# Altium schematic sheet Schematic_Kpad.SchDoc
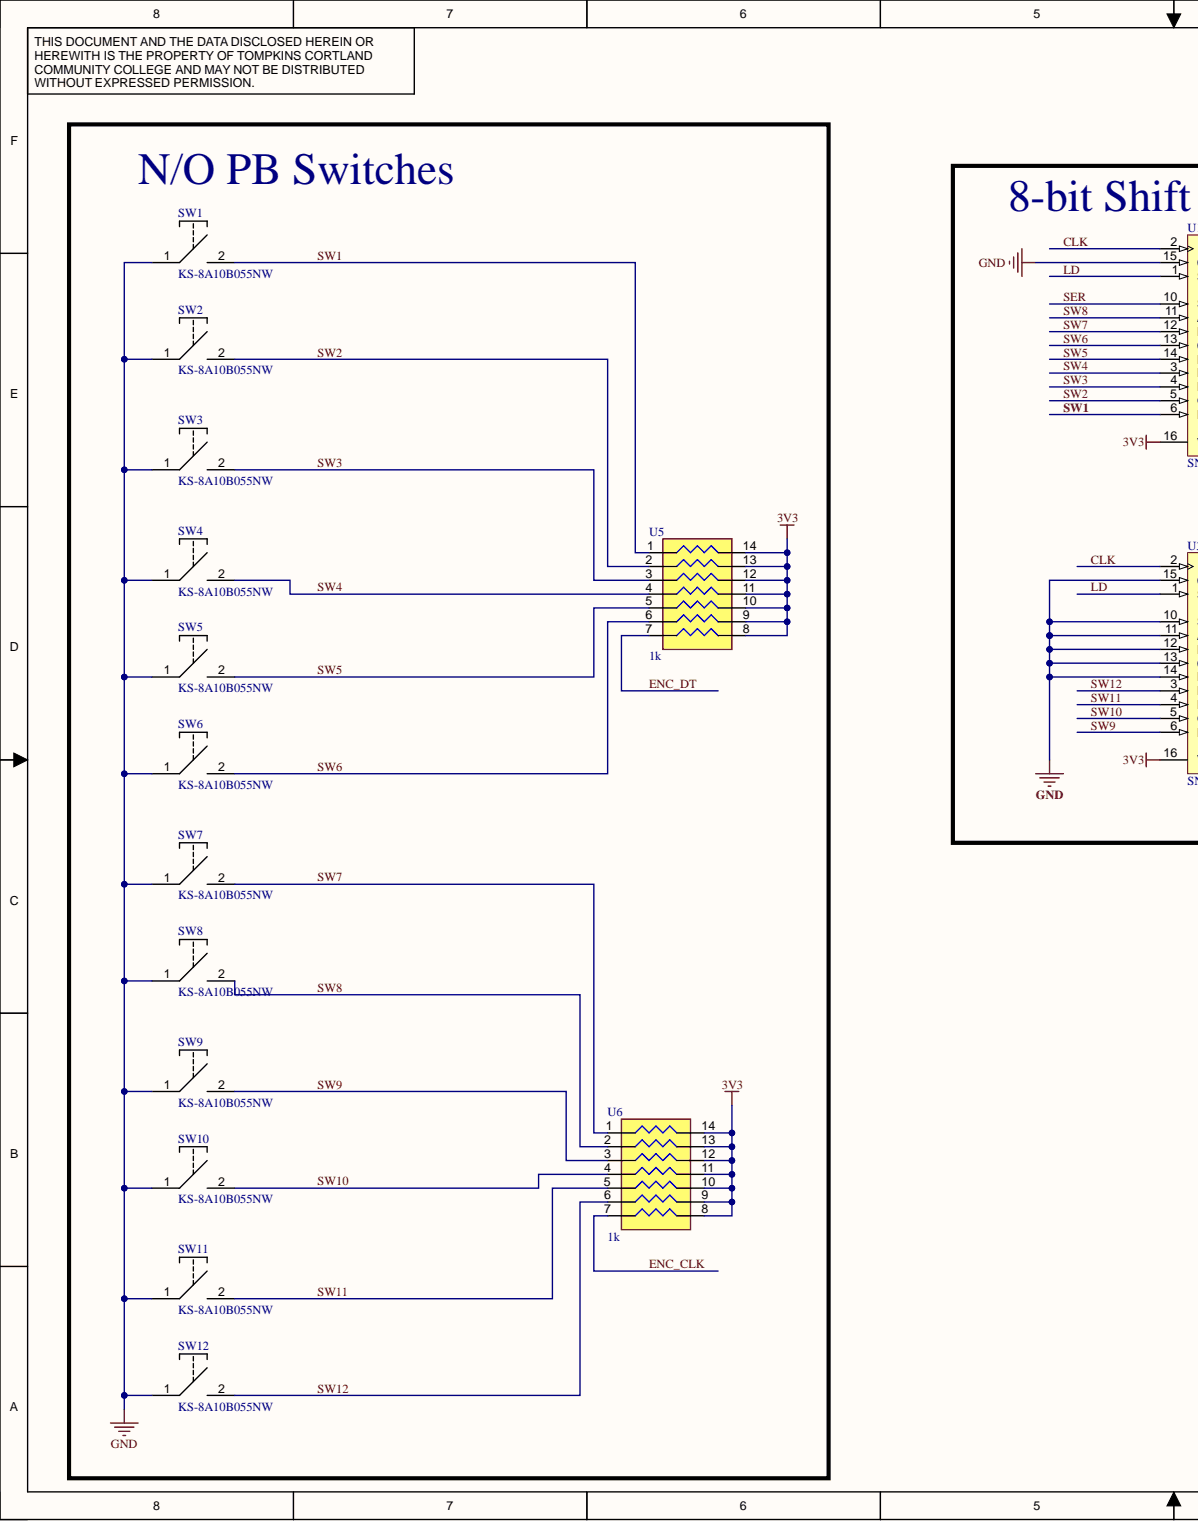
[diagram p0: Schematic_Kpad.SchDoc, left]
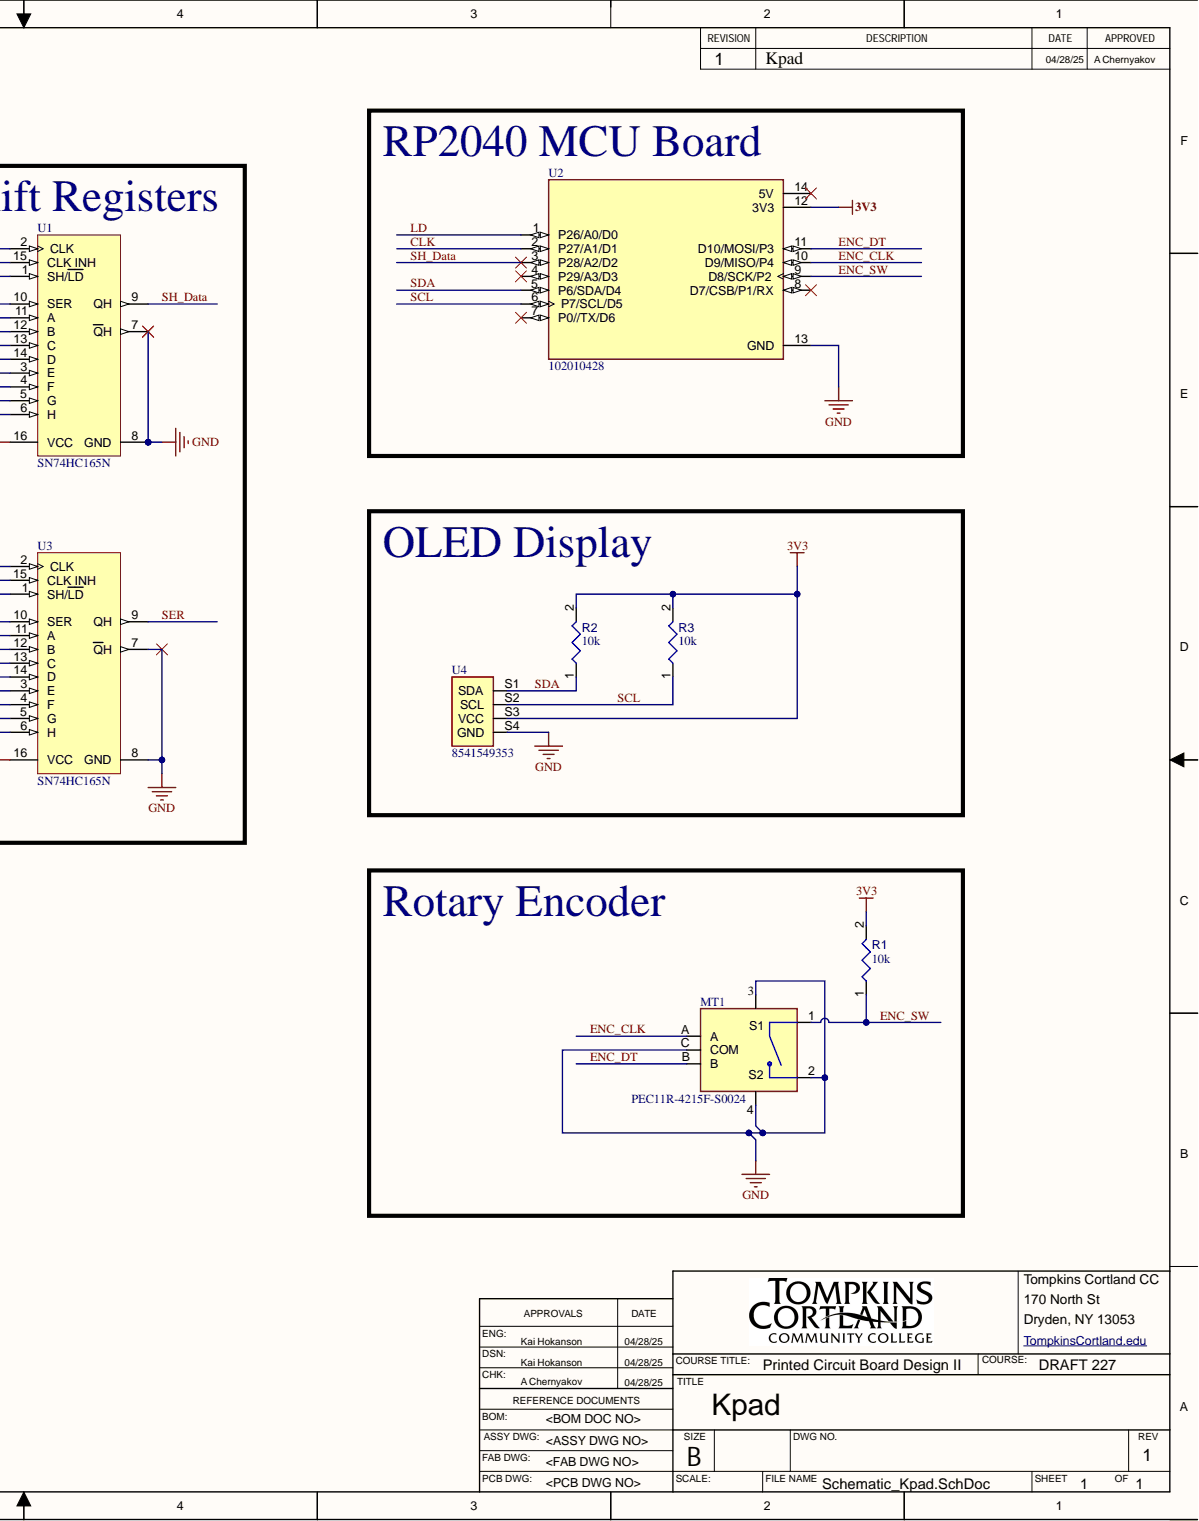
[diagram p1: Schematic_Kpad.SchDoc, right]

## Parts
MT1  PEC11R-4215F-S0024  [FP-PEC11R-4215F-S0024-MFG]
R1  value 10k  [RESA60-630X240]  (hidden comment: MFR-25FBF52-10K)
R2  value 10k  [RESA60-630X240]  (hidden comment: MFR-25FBF52-10K)
R3  value 10k  [RESA60-630X240]  (hidden comment: MFR-25FBF52-10K)
SW1  KS-8A10B055NW  [KS-8A10B055NW-Footprint-1]
SW2  KS-8A10B055NW  [KS-8A10B055NW-Footprint-1]
SW3  KS-8A10B055NW  [KS-8A10B055NW-Footprint-1]
SW4  KS-8A10B055NW  [KS-8A10B055NW-Footprint-1]
SW5  KS-8A10B055NW  [KS-8A10B055NW-Footprint-1]
SW6  KS-8A10B055NW  [KS-8A10B055NW-Footprint-1]
SW7  KS-8A10B055NW  [KS-8A10B055NW-Footprint-1]
SW8  KS-8A10B055NW  [KS-8A10B055NW-Footprint-1]
SW9  KS-8A10B055NW  [KS-8A10B055NW-Footprint-1]
SW10  KS-8A10B055NW  [KS-8A10B055NW-Footprint-1]
SW11  KS-8A10B055NW  [KS-8A10B055NW-Footprint-1]
SW12  KS-8A10B055NW  [KS-8A10B055NW-Footprint-1]
U1  SN74HC165N  [TI-N0016A]
U2  102010428  [MODULE_102010428]
U3  SN74HC165N  [TI-N0016A]
U4  8541549353  [New footprint 1]
U5  value 1k  [DIP794W45P254L1969H508Q14]  (hidden comment: 4114R-1-102LF)
U6  value 1k  [DIP794W45P254L1969H508Q14]  (hidden comment: 4114R-1-102LF)

## Nets
3V3: R1.2 R2.2 R3.2 U1.16(VCC) U2.12(3V3) U3.16(VCC) U4.S3(VCC) U5.8 U5.9 U5.10 U5.11 U5.12 U5.13 U5.14 U6.8 U6.9 U6.10 U6.11 U6.12 U6.13 U6.14
GND: MT1.2 MT1.3(S1) MT1.4(S2) MT1.C(COM) SW1.1 SW2.1 SW3.1 SW4.1 SW5.1 SW6.1 SW7.1 SW8.1 SW9.1 SW10.1 SW11.1 SW12.1 U1.7(QH) U1.8(GND) U1.15(CLK_INH) U2.13(GND) U3.7(QH) U3.8(GND) U3.10(SER) U3.11(A) U3.12(B) U3.13(C) U3.14(D) U3.15(CLK_INH) U4.S4(GND)
CLK: U1.2(CLK) U2.2(P27/A1/D1) U3.2(CLK)
ENC_CLK: MT1.A U2.10(D9/MISO/P4) U6.7
ENC_DT: MT1.B U2.11(D10/MOSI/P3) U5.7
ENC_SW: MT1.1 R1.1 U2.9(D8/SCK/P2)
LD: U1.1(SH/LD) U2.1(P26/A0/D0) U3.1(SH/LD)
SCL: R3.1 U2.6(P7/SCL/D5) U4.S2(SCL)
SDA: R2.1 U2.5(P6/SDA/D4) U4.S1(SDA)
SER: U1.10(SER) U3.9(QH)
SH_Data: U1.9(QH) U2.3(P28/A2/D2)
SW1: SW1.2 U1.6(H) U5.1
SW2: SW2.2 U1.5(G) U5.2
SW3: SW3.2 U1.4(F) U5.3
SW4: SW4.2 U1.3(E) U5.4
SW5: SW5.2 U1.14(D) U5.5
SW6: SW6.2 U1.13(C) U5.6
SW7: SW7.2 U1.12(B) U6.1
SW8: SW8.2 U1.11(A) U6.2
SW9: SW9.2 U3.6(H) U6.3
SW10: SW10.2 U3.5(G) U6.4
SW11: SW11.2 U3.4(F) U6.5
SW12: SW12.2 U3.3(E) U6.6

Not connected: U2 4(P29/A3/D3) 7(P0//TX/D6) 8(D7/CSB/P1/RX) 14(5V)

## Library data of the parts
MT1 = CMP-033-000000-5: Incremental Encoder, 12 Mm, Contact Rating 10 Ma - 5 Vdc; Availability: Unavailable; Component Kind: Standard; Component Type: Standard; Design Item ID: PEC11R-4215F-S0024; MP: PEC11R-4215F-S0024; Package: None; Pin Count: 7; Price: None; RoHS Compliant: Yes; Source: PEC11R-4215F-S0024.SchLib; Library Name: Tompkins Cortland Community College
R1-R3 = CMP-009-00047-4: Axial Resistor, 10 KOhm, +/- 1%, 0.25 W, -55 to 155 degC, 2-Pin THD, RoHS, Bulk; Manufacturer: Yageo; Power: 250mW; Voltage Rating: 250V; Case/Package: Axial; Component Kind: Standard; Datasheet URL: http://www.yageo.com/documents/recent/Yageo%20LR_MFR_2013.pdf; Datasheet Version: Apr-13; Manufacturer URL: http://www.yageo.com/; Max Operating Temperature: 155°C; Min Operating Temperature: -55°C; Mounting Technology: TH; Package Description: 2-Pin Axial Lead, Dia 2.4 mm, Body Length 6.3 mm; Package Quantity: 200; Package Reference: MFR-25; Packaging: Bulk; RoHS Compliant: True; Tolerance: 1%; Library Name: Tompkins Cortland Community College
SW1-SW12 = CMP-011-00015-12: SPST momentary switch 15.40mmx15.40mm; Manufacturer 1: Gateron; Manufacturer Part Number 1: KS-8A10B055NW-D194; Current Rating: 10mA; Voltage Rating: 12V; Component Kind: Standard; ComponentLink1Description: Datasheet; ComponentLink1URL: https://gateron.com/u_file/2311/10/file/GATERONOilkingswitch-KS-8A10B055NW-D53.pdf; Max Current Rating: 10mA; Max Operating Temperature: 80°C; Min Operating Temperature: -40°C; Mounting Technology: TH; Pins: 4; Supplier 1: Divinikey; Supplier Part Number 1: DK002607; Throw Configuration: SPST; Library Name: Tompkins Cortland Community College
U1 U3 = CMP-016-00000-4: Parallel-Load Shift Register, 8-Bit, 2 to 6 V, -40 to 125 degC, 16-Pin PDIP (N), Pb-Free (RoHS), Rail/Tube; Manufacturer 1: Texas Instruments; Direction: Unidirectional; Elements: 1; Max Ouput Current: 5.2mA; Max Supply Voltage: 6V; Min Supply Voltage: 2V; Nominal Supply Voltage: 5V; Package Version: 4040049/E, 12/2002; Propagation Delay: 150ns; Case/Package: PDIP; Component Kind: Standard; Datasheet URL: http://www.ti.com/lit/ds/symlink/sn74hc165.pdf; Datasheet Version: SCLS116H, 12/2015; Logic Function: ShiftRegister; Manufacturer Part Number 1: SN74HC165N; Manufacturer URL: http://www.ti.com/; Max Operating Temperature: 85°C; Min Operating Temperature: -40°C; Mounting Technology: TH; Output Current: 5.2mA; Package Description: 16-Pin Plastic Double In-line Package, Body 19.305 x 6.35 mm, Pitch 2.54 mm; Package Quantity: 25; Package Reference: PDIP-16; Package URL: http://www.ti.com/lit/ml/mpdi002c/mpdi002c.pdf; Packaging: Rail/Tube; Pins: 16; RoHS Compliant: True; Supplier 1: Digikey; Supplier Part Number 1: 296-8251-5-ND; Library Name: Tompkins Cortland Community College
U2 = CMP-031-000000-3: RP2040 XIAO RP2040 series ARMÂ® CortexÂ®-M0+ MCU 32-Bit Embedded Evaluation Board; Manufacturer 1: Seeed Technology Co., Ltd; Check_prices: https://www.snapeda.com/parts/102010428/Seeed+Technology+Co.%252C+Ltd/view-part/?ref=eda; Component Kind: Standard; Component Type: Standard; Design Item ID: 102010428; Manufacturer Part Number 1: 102010428; MP: 102010428; Package: None; Pin Count: 14; SnapEDA_Link: https://www.snapeda.com/parts/102010428/Seeed+Technology+Co.%252C+Ltd/view-part/?ref=snap; Source: 102010428.SchLib; Supplier 1: Digikey; Supplier Part Number 1: 1597-102010428-ND; Library Name: Tompkins Cortland Community College
U4 = CMP-003-000002-7: MakerFocus 2pcs I2C OLED Display Module 0.91 Inch; Manufacturer 1: Shenzhen Jin Ma Ding Electronics Co., Ltd.; Manufacturer Part Number 1: QG-2832TLBFG02; Component Kind: Standard; Product Manual: https://drive.google.com/file/d/14A_luFwqvZaKu-PKTfJdWmUZ4T4Wk65X/view; Supplier 1: MakerFocus; Supplier Part Number 1: 8541549353; Library Name: Tompkins Cortland Community College
U5 U6 = CMP-009-00186-1: RES ARRAY 7 RES 1K OHM 14DIP; Manufacturer 1: Bourns; Manufacturer Series: 4100R; Channels: 7; Element Power Dissipation: 250mW; First Element Resistance: 1kR; Lead Length: 3.43mm; Network Type: Isolated; Number of Elements: 1; Package Height: 4.57mm; Package Length: 19.43mm; Package Width: 7.87mm; Rated Temperature: 70°C; Temperature Coefficient: 100ppm/°C; Temperature Coefficient Tracking: 50ppm/°C; Tolerance: 2%; Working Voltage: 100V; Case/Package: 7731; Check_prices: https://www.snapeda.com/parts/SN74LS90N/Texas%20Instruments/view-part/386057/?ref=eda; Component Kind: Standard; Component Type: Standard; ComponentLink1Description: Datasheet; ComponentLink1URL: https://www.bourns.com/docs/Product-Datasheets/4100R.pdf; Construction: Molded; Design Item ID: 4114R-1-102LF; Digi-Key_Part_Number: 4114R-1-102LF-ND; JESD-609 Code: e3; Lead Pitch: 2.54mm; Manufacturer Part Number 1: 4114R-1-102LF; Max Operating Temperature: 125°C; Min Operating Temperature: -55°C; Mounting Technology: Through Hole; Package: PDIP-14; Package Shape: Rectangular; Package Style: DIPMeter; Pin Count: 12; Pins: 14; Power: 250mW; Reach SVHC Compliant: No; Resistor Type: ARRAY/NETWORK RESISTOR; RoHS Compliant: Yes; Series: 4100R; Technology: METAL GLAZE/THICK FILM; Terminal Finish: Tin; Termination: Flat; Library Name: Tompkins Cortland Community College
